# Altium schematic sheet MIPI_CSI.SchDoc(MIPI_CSI)
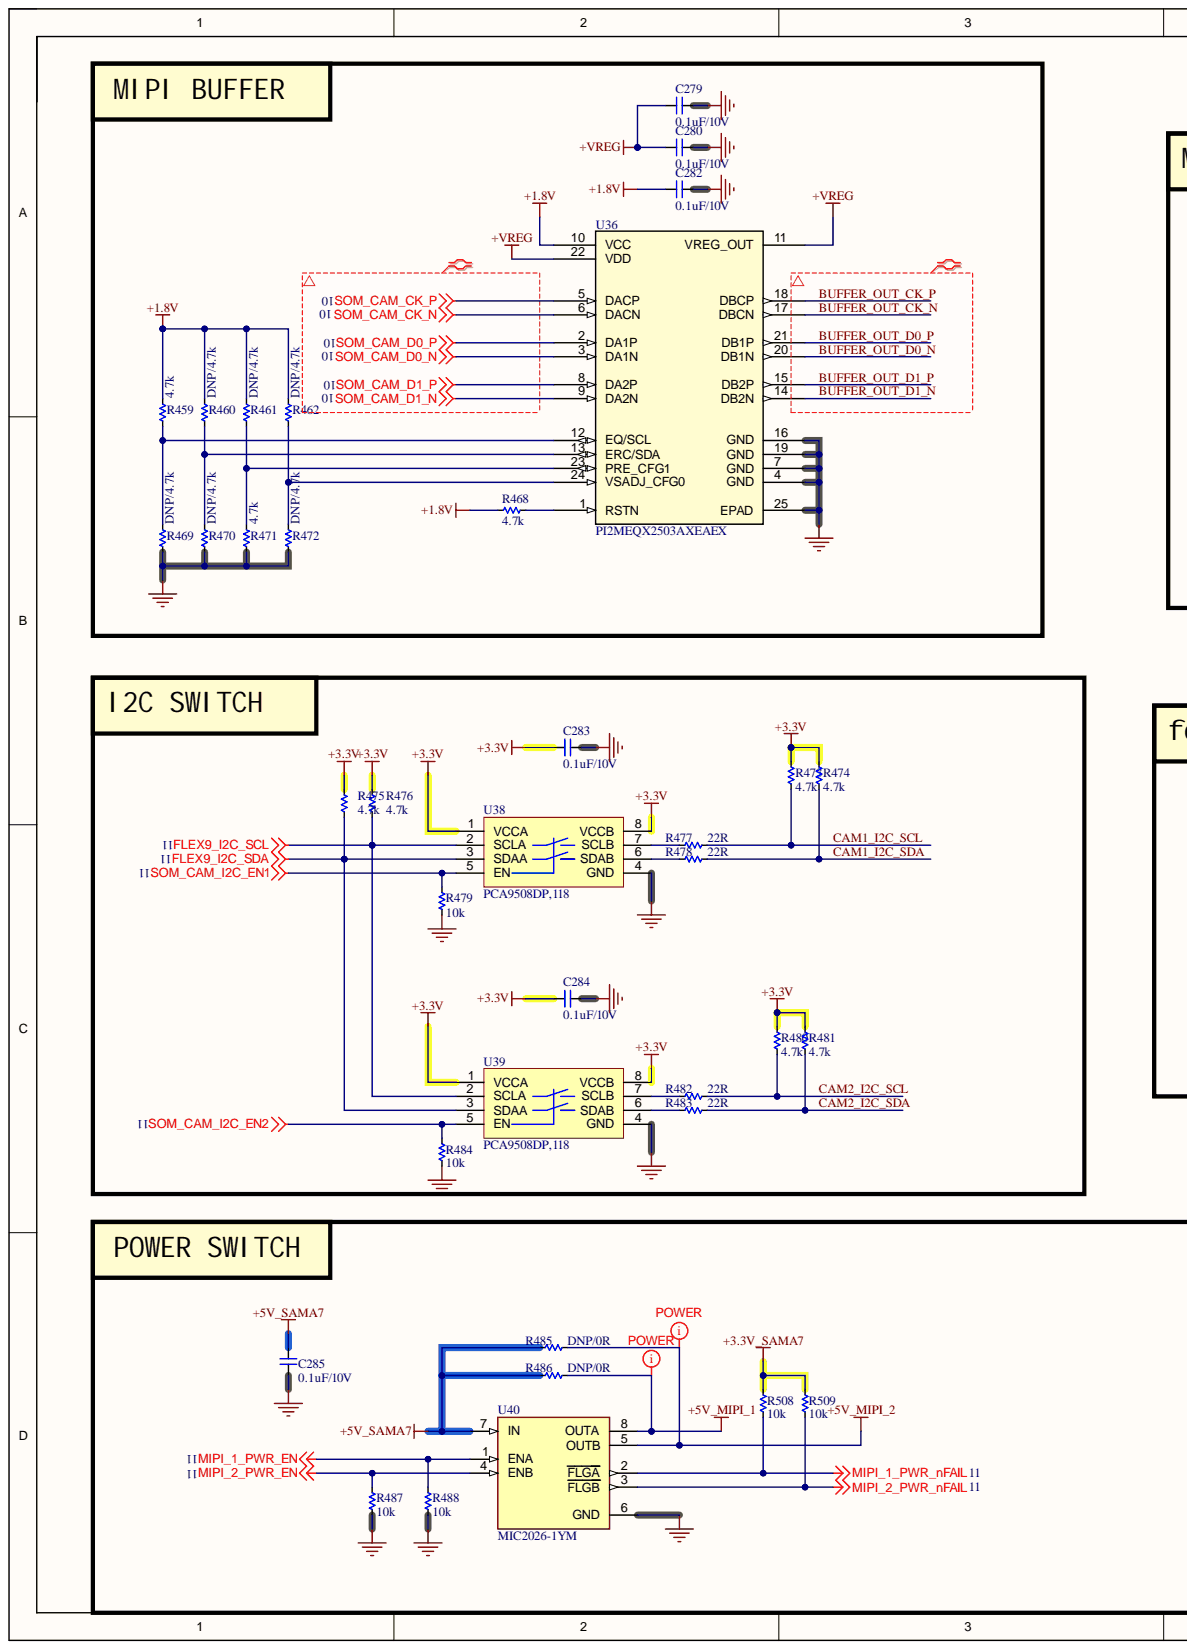
[diagram p0: MIPI_CSI.SchDoc, left]
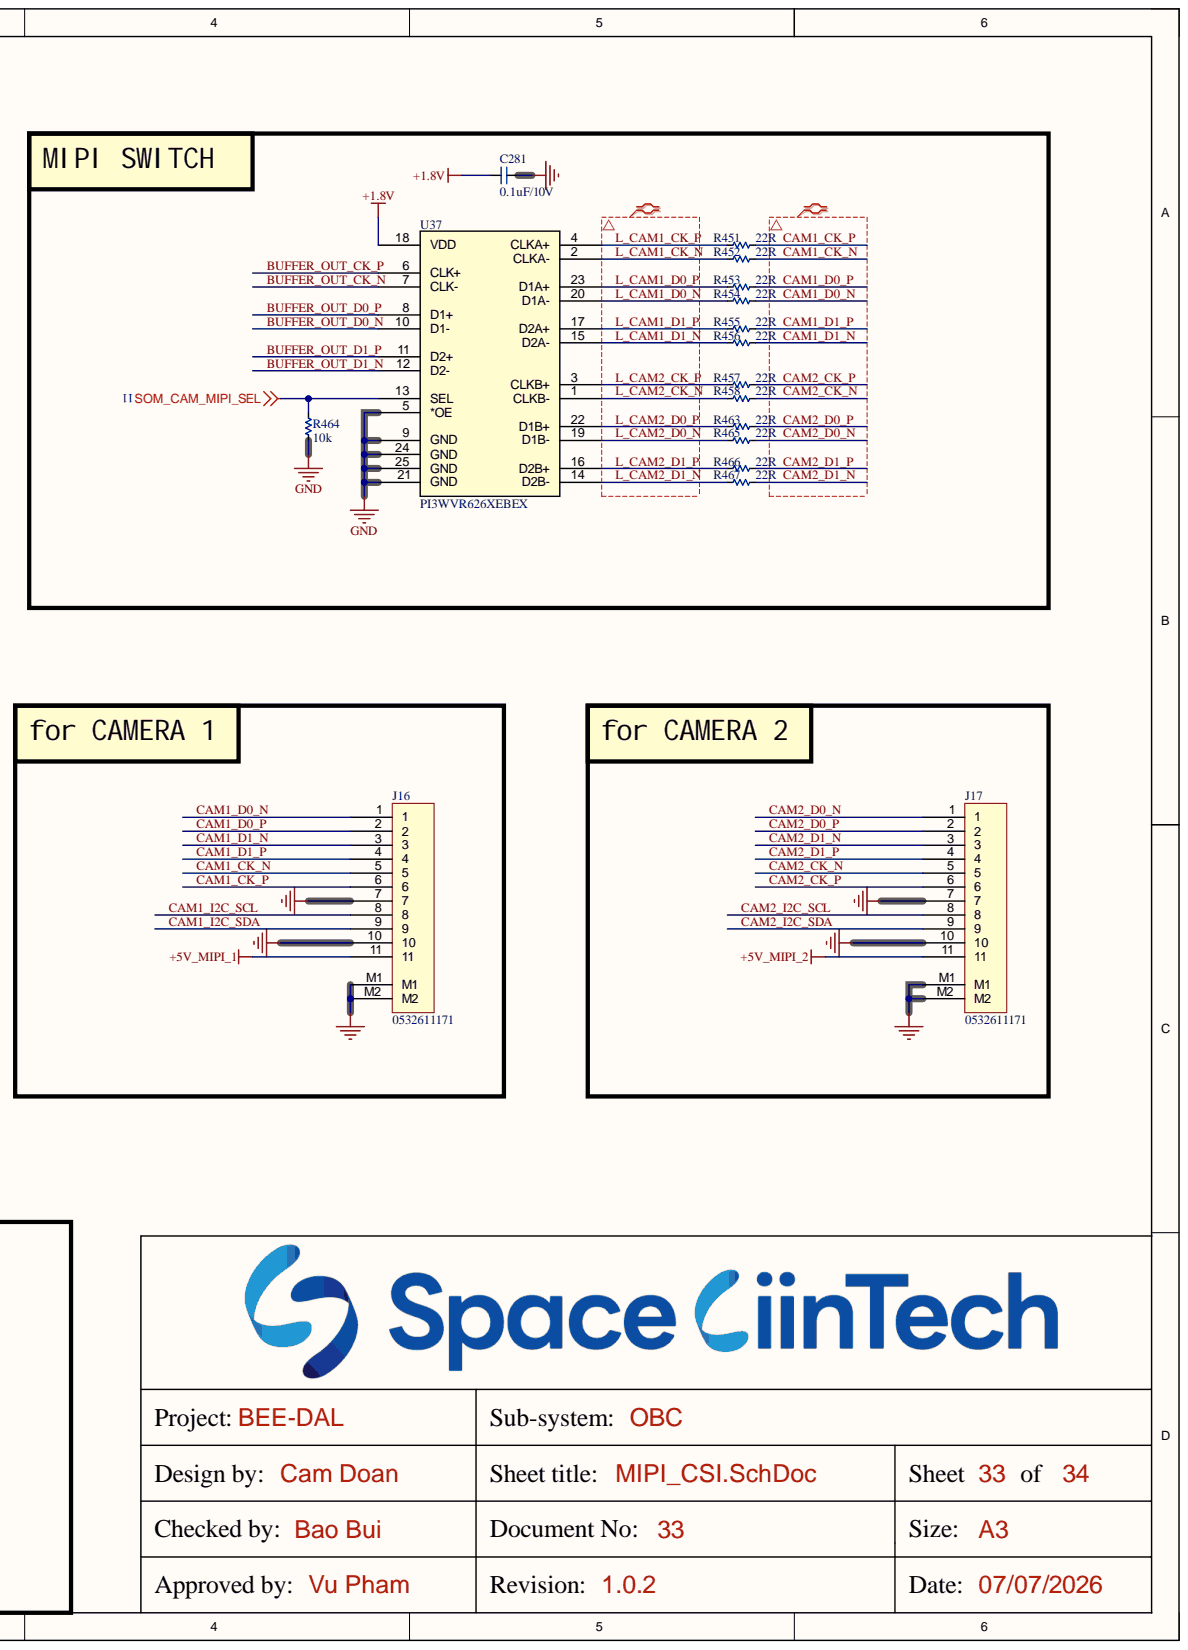
[diagram p1: MIPI_CSI.SchDoc, right]

## Parts
C279  0.1uF/10V  [CAP_C_1005X60]
C280  0.1uF/10V  [CAP_C_1005X60]
C281  0.1uF/10V  [CAP_C_1005X60]
C282  0.1uF/10V  [CAP_C_1005X60]
C283  0.1uF/10V  [CAP_C_1005X60]
C284  0.1uF/10V  [CAP_C_1005X60]
C285  0.1uF/10V  [CAP_C_1005X60]
J16  0532611171  [MOLEX_0532611171]
J17  0532611171  [MOLEX_0532611171]
R451  22R  [RES_C_1005X40]
R452  22R  [RES_C_1005X40]
R453  22R  [RES_C_1005X40]
R454  22R  [RES_C_1005X40]
R455  22R  [RES_C_1005X40]
R456  22R  [RES_C_1005X40]
R457  22R  [RES_C_1005X40]
R458  22R  [RES_C_1005X40]
R459  4.7k  [RES_C_1608X60]
R460  DNP/4.7k  [RES_C_1608X60]
R461  DNP/4.7k  [RES_C_1608X60]
R462  DNP/4.7k  [RES_C_1608X60]
R463  22R  [RES_C_1005X40]
R464  10k  [RES_C_1005X40]
R465  22R  [RES_C_1005X40]
R466  22R  [RES_C_1005X40]
R467  22R  [RES_C_1005X40]
R468  4.7k  [RES_C_1005X40]
R469  DNP/4.7k  [RES_C_1608X60]
R470  DNP/4.7k  [RES_C_1608X60]
R471  4.7k  [RES_C_1608X60]
R472  DNP/4.7k  [RES_C_1608X60]
R473  4.7k  [RES_C_1005X40]
R474  4.7k  [RES_C_1005X40]
R475  4.7k  [RES_C_1005X40]
R476  4.7k  [RES_C_1005X40]
R477  22R  [RES_C_1005X40]
R478  22R  [RES_C_1005X40]
R479  10k  [RES_C_1005X40]
R480  4.7k  [RES_C_1005X40]
R481  4.7k  [RES_C_1005X40]
R482  22R  [RES_C_1005X40]
R483  22R  [RES_C_1005X40]
R484  10k  [RES_C_1005X40]
R485  DNP/0R  [RES_C_1005X40]
R486  DNP/0R  [RES_C_1005X40]
R487  10k  [RES_C_1005X40]
R488  10k  [RES_C_1005X40]
R508  10k  [RES_C_1005X40]
R509  10k  [RES_C_1005X40]
U36  PI2MEQX2503AXEAEX  [PD-2190_DIO]  (value not drawn)
U37  PI3WVR626XEBEX  [PI3WVR626XEBEX_DIO]
U38  PCA9508DP,118  [TSOP8]
U39  PCA9508DP,118  [TSOP8]
U40  MIC2026-1YM  [SOIC127P602X173-8N]

## Nets
+1.8V: C281.2 C282.2 R459.1 R460.1 R461.1 R462.1 R468.1 U36.10(VCC) U37.18(VDD)
+3.3V: C283.2 C284.2 R473.1 R474.1 R475.1 R476.1 R480.1 R481.1 U38.1(VCCA) U38.8(VCCB) U39.1(VCCA) U39.8(VCCB)
+3.3V_SAMA7: R508.1 R509.1
+5V_MIPI_1: J16.11 R486.1 U40.8(OUTA)
+5V_MIPI_2: J17.11 R485.1 U40.5(OUTB)
+5V_SAMA7: C285.2 R485.2 R486.2 U40.7(IN)
+VREG: C279.2 C280.2 U36.11(VREG_OUT) U36.22(VDD)
FLEX9_I2C_SCL: R476.2 U38.2(SCLA) U39.2(SCLA)
FLEX9_I2C_SDA: R475.2 U38.3(SDAA) U39.3(SDAA)
GND: C279.1 C280.1 C281.1 C282.1 C283.1 C284.1 C285.1 J16.7 J16.10 J16.M1 J16.M2 J17.7 J17.10 J17.M1 J17.M2 R464.1 R469.2 R470.2 R471.2 R472.2 R479.2 R484.2 R487.2 R488.2 U36.4(GND) U36.7(GND) U36.16(GND) U36.19(GND) U36.25(EPAD) U37.5(*OE) U37.9(GND) U37.21(GND) U37.24(GND) U37.25(GND) U38.4(GND) U39.4(GND) U40.6(GND)
MIPI_1_PWR_EN: R488.1 U40.1(ENA)
MIPI_1_PWR_nFAIL: R508.2 U40.2(FLGA)
MIPI_2_PWR_EN: R487.1 U40.4(ENB)
MIPI_2_PWR_nFAIL: R509.2 U40.3(FLGB)
SOM_CAM_CK_N: U36.6(DACN)
SOM_CAM_CK_P: U36.5(DACP)
SOM_CAM_D0_N: U36.3(DA1N)
SOM_CAM_D0_P: U36.2(DA1P)
SOM_CAM_D1_N: U36.9(DA2N)
SOM_CAM_D1_P: U36.8(DA2P)
SOM_CAM_I2C_EN1: R479.1 U38.5(EN)
SOM_CAM_I2C_EN2: R484.1 U39.5(EN)
SOM_CAM_MIPI_SEL: R464.2 U37.13(SEL)
BUFFER_OUT_CK_N: U36.17(DBCN) U37.7(CLK-)
BUFFER_OUT_CK_P: U36.18(DBCP) U37.6(CLK+)
BUFFER_OUT_D0_N: U36.20(DB1N) U37.10(D1-)
BUFFER_OUT_D0_P: U36.21(DB1P) U37.8(D1+)
BUFFER_OUT_D1_N: U36.14(DB2N) U37.12(D2-)
BUFFER_OUT_D1_P: U36.15(DB2P) U37.11(D2+)
CAM1_CK_N: J16.5 R452.2
CAM1_CK_P: J16.6 R451.2
CAM1_D0_N: J16.1 R454.2
CAM1_D0_P: J16.2 R453.2
CAM1_D1_N: J16.3 R456.2
CAM1_D1_P: J16.4 R455.2
CAM1_I2C_SCL: J16.8 R473.2 R477.2
CAM1_I2C_SDA: J16.9 R474.2 R478.2
CAM2_CK_N: J17.5 R458.2
CAM2_CK_P: J17.6 R457.2
CAM2_D0_N: J17.1 R465.2
CAM2_D0_P: J17.2 R463.2
CAM2_D1_N: J17.3 R467.2
CAM2_D1_P: J17.4 R466.2
CAM2_I2C_SCL: J17.8 R480.2 R482.2
CAM2_I2C_SDA: J17.9 R481.2 R483.2
L_CAM1_CK_N: R452.1 U37.2(CLKA-)
L_CAM1_CK_P: R451.1 U37.4(CLKA+)
L_CAM1_D0_N: R454.1 U37.20(D1A-)
L_CAM1_D0_P: R453.1 U37.23(D1A+)
L_CAM1_D1_N: R456.1 U37.15(D2A-)
L_CAM1_D1_P: R455.1 U37.17(D2A+)
L_CAM2_CK_N: R458.1 U37.1(CLKB-)
L_CAM2_CK_P: R457.1 U37.3(CLKB+)
L_CAM2_D0_N: R465.1 U37.19(D1B-)
L_CAM2_D0_P: R463.1 U37.22(D1B+)
L_CAM2_D1_N: R467.1 U37.14(D2B-)
L_CAM2_D1_P: R466.1 U37.16(D2B+)
NetR459_2: R459.2 R469.1 U36.12(EQ/SCL)
NetR460_2: R460.2 R470.1 U36.13(ERC/SDA)
NetR461_2: R461.2 R471.1 U36.23(PRE_CFG1)
NetR462_2: R462.2 R472.1 U36.24(VSADJ_CFG0)
NetR468_2: R468.2 U36.1(RSTN)
NetR477_1: R477.1 U38.7(SCLB)
NetR478_1: R478.1 U38.6(SDAB)
NetR482_1: R482.1 U39.7(SCLB)
NetR483_1: R483.1 U39.6(SDAB)

## Library data of the parts
C279-C284 = _CAP_C_2: CAP CHIP; Component Kind: Standard; Library Name: LABLIB.SchLib
C285 = _CAP_C_2: CAP; Component Kind: Standard; Library Name: LABLIB.SchLib
J16 J17 = Header 11x1_2M: Component Kind: Standard; Library Name: LABLIB.SchLib
R451-R488 R508 R509 = _RES_B_2: RES; Component Kind: Standard; Library Name: LABLIB.SchLib
U36 = IC_MIPI_BUFER_PI2MEQX2503AXEAEX: No Description Available; Manufacturer_Name: Diodes Inc; Manufacturer_Part_Number: PI2MEQX2503XEAEX; Component Kind: Standard; RefDes: RefDes; Type: Interface; Copyright: Copyright (C) 2026 Ultra Librarian. All rights reserved.; Library Name: LABLIB.SchLib
U37 = PI3WVR626XEBEX: Mfr_Name: Diodes Inc; Component Kind: Standard; Description: PI3WVR626XEBEX; Library Name: CAMERA_SchLib.SchLib; RefDes: RefDes; Type: Type
U40 = IC_PWRSW_MIC2026-1YM: Power Switch/Driver 1:2 N-Channel 1.25A 8-SOIC; MF: Microchip; Check_prices: https://www.snapeda.com/parts/MIC2026-1YM/Microchip/view-part/?ref=eda; Component Kind: Standard; MP: MIC2026-1YM; Package: SO-8 Microchip; SnapEDA_Link: https://www.snapeda.com/parts/MIC2026-1YM/Microchip/view-part/?ref=snap; Library Name: LABLIB.SchLib
U38 U39 = PCA9508DP,118: Component Kind: Standard; Library Name: EXP_HW_V3.SchLib
